# Altium schematic sheet GameBoy EXT Port.SchDoc("GameBoy EXT Port")
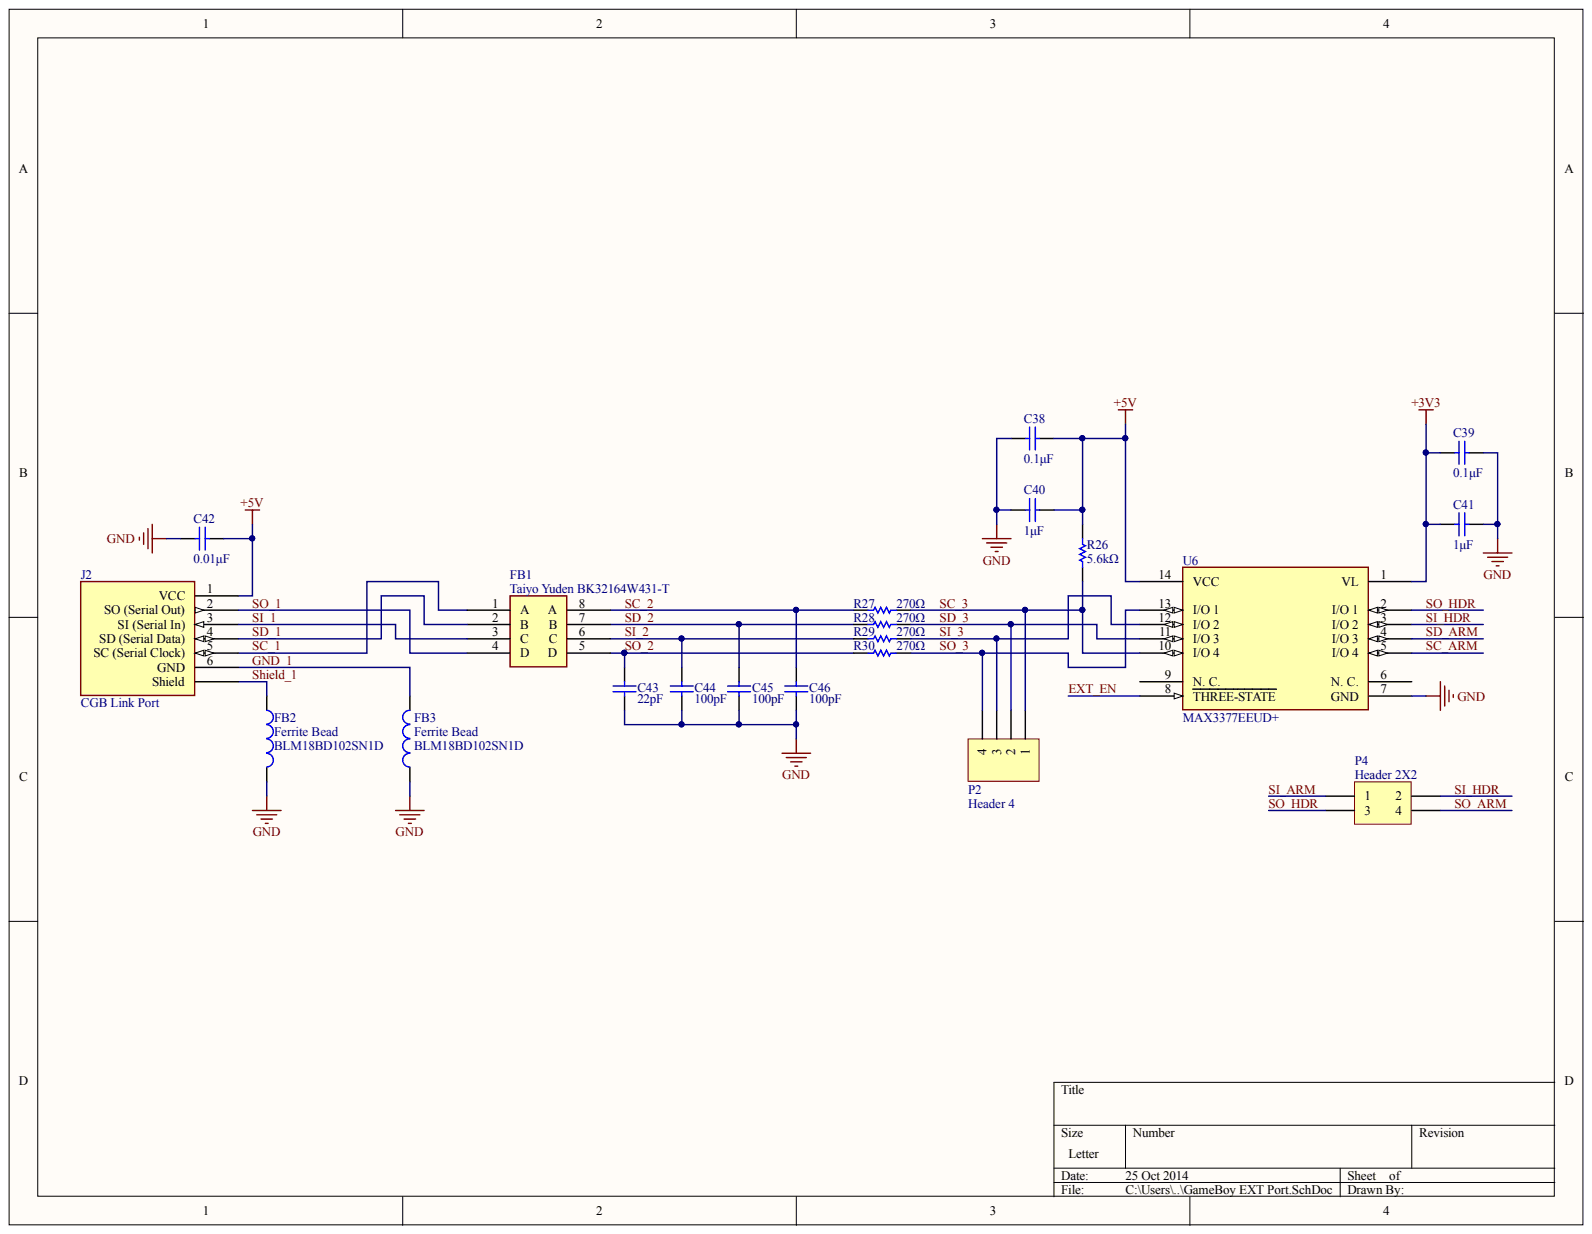
[diagram p0: GameBoy EXT Port.SchDoc]

## Parts
C38  value 0.1μF  [C0805]  (hidden comment: Cap)
C39  value 0.1μF  [C0805]  (hidden comment: Cap)
C40  value 1μF  [C0805]  (hidden comment: Cap)
C41  value 1μF  [C0805]  (hidden comment: Cap)
C42  value 0.01μF  [C0805]  (hidden comment: Cap)
C43  value 22pF  [C0805]  (hidden comment: Cap)
C44  value 100pF  [C0805]  (hidden comment: Cap)
C45  value 100pF  [C0805]  (hidden comment: Cap)
C46  value 100pF  [C0805]  (hidden comment: Cap)
FB1  Taiyo Yuden BK32164W431-T  [1206 (3216 Metric), Array, 8 PC Pad]
FB2  Ferrite Bead  value BLM18BD102SN1D  [1608[0603]]
FB3  Ferrite Bead  value BLM18BD102SN1D  [1608[0603]]
J2  CGB Link Port  [CGB Link Port]
P2  Header 4  [HDR1X4]
P4  Header 2X2  [HDR2X2]
R26  value 5.6kΩ  [C0805]  (hidden comment: Res1)
R27  value 270Ω  [C0805]  (hidden comment: Res1)
R28  value 270Ω  [C0805]  (hidden comment: Res1)
R29  value 270Ω  [C0805]  (hidden comment: Res1)
R30  value 270Ω  [C0805]  (hidden comment: Res1)
U6  MAX3377EEUD+  [Maxim TSSOP 21-0066]

## Nets
+3V3: C39.2 C41.1 U6.1(VL)
+5V: C38.1 C40.1 C42.1 J2.1(VCC) R26.1 U6.14(VCC)
GND: C38.2 C39.1 C40.2 C41.2 C42.2 C43.1 C44.1 C45.1 C46.1 FB2.2 FB3.1 U6.7(GND)
EXT_EN: U6.8(THREE-STATE)
GND_1: FB3.2 J2.6(GND)
SC_1: FB1.1(A) J2.5(SC_(Serial_Clock))
SC_2: C46.2 FB1.8(A) R27.2
SC_3: P2.1 R26.2 R27.1 U6.10(I/O_4)
SC_ARM: U6.5(I/O_4)
SD_1: FB1.2(B) J2.4(SD_(Serial_Data))
SD_2: C45.2 FB1.7(B) R28.2
SD_3: P2.2 R28.1 U6.11(I/O_3)
SD_ARM: U6.4(I/O_3)
SHIELD_1: FB2.1 J2.7(Shield)
SI_1: FB1.3(C) J2.3(SI_(Serial_In))
SI_2: C44.2 FB1.6(C) R29.2
SI_3: P2.3 R29.1 U6.12(I/O_2)
SI_ARM: P4.1
SI_HDR: P4.2 U6.3(I/O_2)
SO_1: FB1.4(D) J2.2(SO_(Serial_Out))
SO_2: C43.2 FB1.5(D) R30.2
SO_3: P2.4 R30.1 U6.13(I/O_1)
SO_ARM: P4.4
SO_HDR: P4.3 U6.2(I/O_1)

Not connected: U6 6(N._C.) 9(N._C.)

## Library data of the parts
C38-C46 = Cap: Capacitor; Component Kind: Standard; LatestRevisionDate: 17-Jul-2002; LatestRevisionNote: Re-released for DXP Platform.; Library Name: Miscellaneous Devices.IntLib; PackageDescription: Radial Cap, Thru-Hole; 2 Leads; 0.3 in Pin Spacing; PackageReference: RAD-0.3; Published: 8-Jun-2000; Signal Integrity: Cap; Simulation: CAP; Publisher: Altium Limited
FB1 = Taiyo Yuden BK32164W431-T: FERRITE BEAD ARRAY 430 OHM 1206; Component Kind: Standard; Library Name: TS-Schematic.SchLib
FB2 FB3 = Inductor: Code_IEC: 1005; Code_JEITA: 0402; Component Kind: Standard; Description: Inductor; LatestRevisionDate: 29-May-2009; LatestRevisionNote: IPC-7351 Footprint Added.; Library Name: Miscellaneous Devices.IntLib; PackageDescription: Chip Inductor; PackageReference: 0402-A; Published: 8-Jun-2000; Signal Integrity: Inductor; Simulation: INDUCTOR; Publisher: Altium Limited
J2 = CGB Link Port: Nintendo GameBoy Color Link Port; Component Kind: Standard; Library Name: TS-Schematic.SchLib
P2 = Header 4: Header, 4-Pin; Component Kind: Standard; LatestRevisionDate: 17-Jul-2002; LatestRevisionNote: Re-released for DXP Platform.; Library Name: Miscellaneous Connectors.IntLib; Signal Integrity: Connector; Publisher: Altium Limited
P4 = Header 2X2: Header, 2-Pin, Dual row; Component Kind: Standard; LatestRevisionDate: 17-Jul-2002; LatestRevisionNote: Re-released for DXP Platform.; Library Name: Miscellaneous Connectors.IntLib; Signal Integrity: Connector; Publisher: Altium Limited
R26-R30 = Res1: Resistor; Component Kind: Standard; LatestRevisionDate: 17-Jul-2002; LatestRevisionNote: Re-released for DXP Platform.; Library Name: Miscellaneous Devices.IntLib; PackageDescription: Axial Device, Thru-Hole; 2 Leads; 0.3 in Pin Spacing; PackageReference: AXIAL-0.3; Published: 8-Jun-2000; Signal Integrity: Res; Simulation: RESISTOR; Publisher: Altium Limited
U6 = MAX3377EEUD+: Maxim Level Shifter - 4 Bi; Component Kind: Standard; Library Name: TS-Schematic.SchLib
